# Altium schematic sheet power-board_E3_schematic.SchDoc
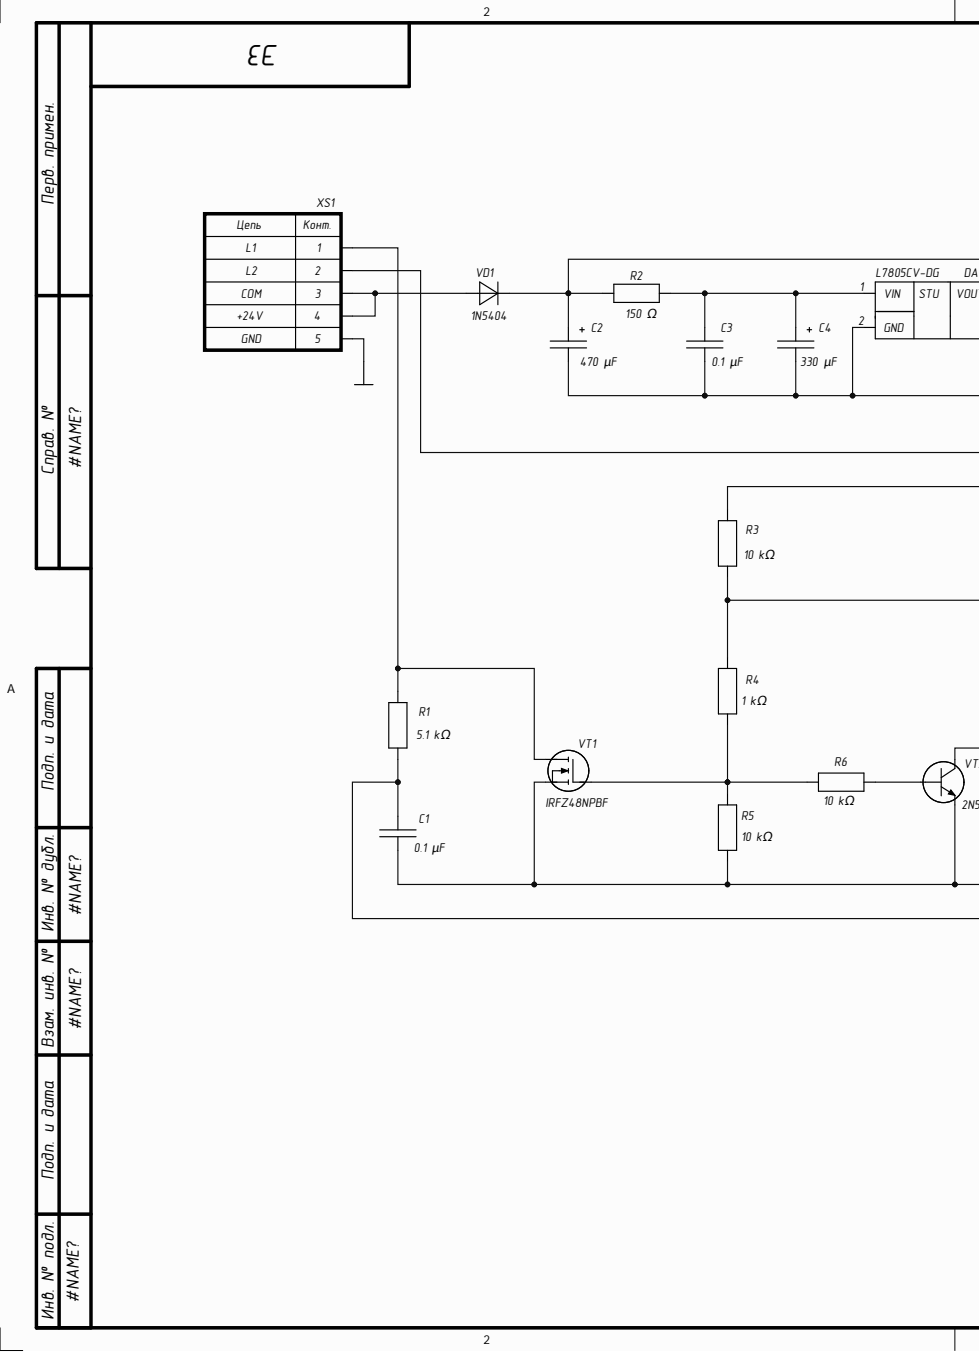
[diagram p0: power-board_E3_schematic.SchDoc, left]
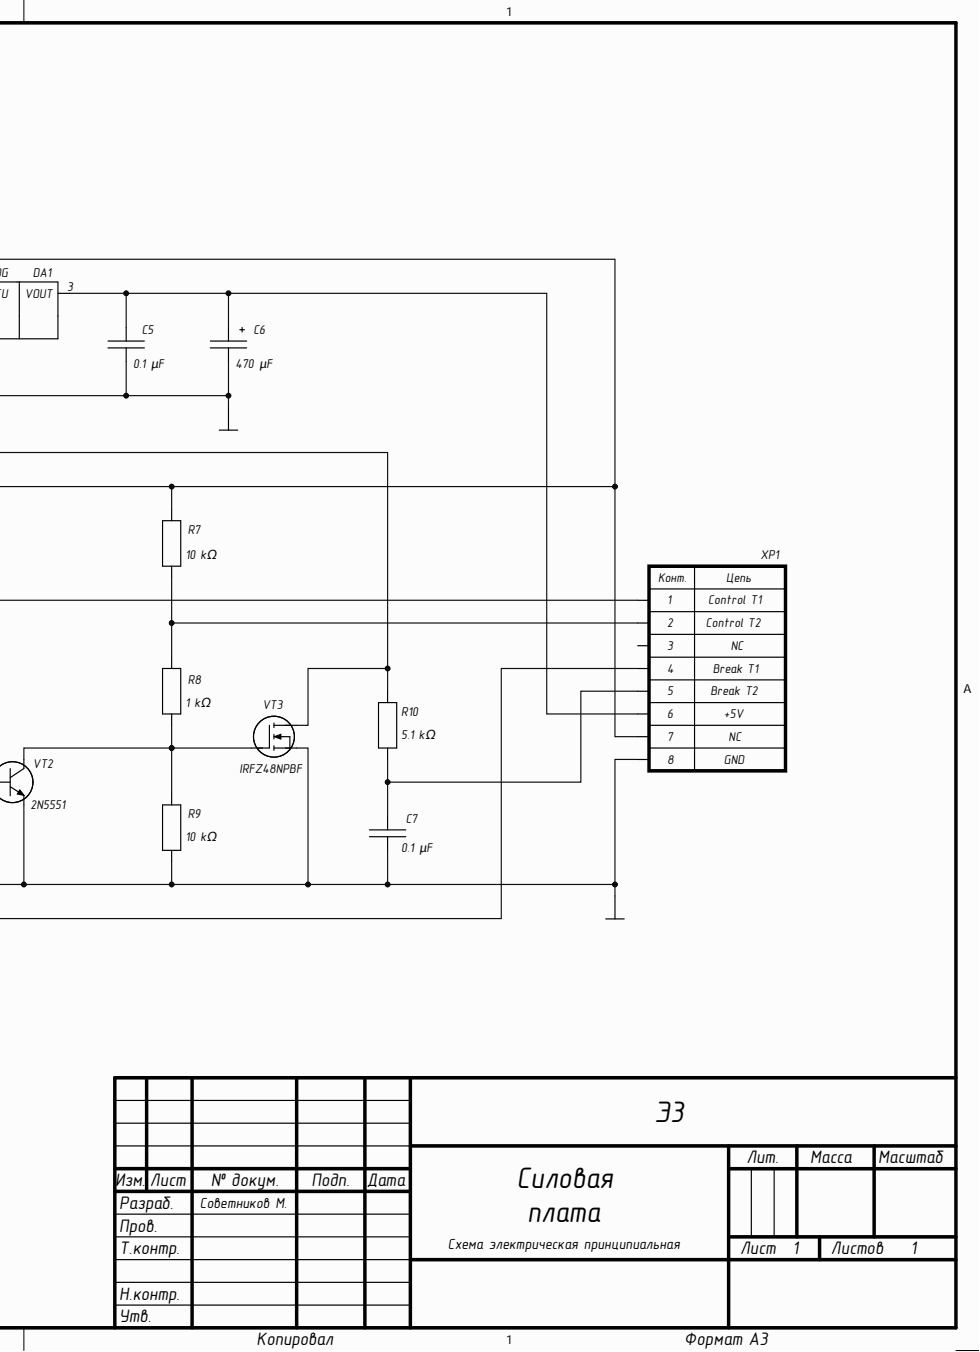
[diagram p1: power-board_E3_schematic.SchDoc, right]

## Parts
C1  0.1 µF  [C_MLCC]
C2  470 µF  [C_Polarized_D10_H16.5]
C3  0.1 µF  [C_MLCC]
C4  330 µF  [C_Polarized_D8_H16.5]
C5  0.1 µF  [C_MLCC]
C6  470 µF  [C_Polarized_D8_H13]
C7  0.1 µF  [C_MLCC]
DA1  L7805CV-DG  [DA_TO-220]
R1  5.1 kΩ  [R_CF-25_C1-4]
R2  150 Ω  [R_C2-23]
R3  10 kΩ  [R_CF-25_C1-4]
R4  1 kΩ  [R_CF-25_C1-4]
R5  10 kΩ  [R_CF-25_C1-4]
R6  10 kΩ  [R_CF-25_C1-4]
R7  10 kΩ  [R_CF-25_C1-4]
R8  1 kΩ  [R_CF-25_C1-4]
R9  10 kΩ  [R_CF-25_C1-4]
R10  5.1 kΩ  [R_CF-25_C1-4]
VD1  1N5404  [VD_DO-201AD]
VT1  IRFZ48NPBF  [VT_TO-220AB]
VT2  2N5551  [VT_TO-92]
VT3  IRFZ48NPBF  [VT_TO-220AB]
XP1  L-KLS1-2.50-08-R  [X_CWF-8R]  (value not drawn)
XS1  DG35C-B-05P-13-200A(H)  [X_DG35C-05PIN]  (value not drawn)

## Nets
GND: C1.1 C2.2 C3.1 C4.2 C5.1 C6.2 C7.1 DA1.2 R5.1 R9.2 VT1.1 VT2.3 VT3.1 XP1.8 XS1.5
NetC1_2: C1.2 R1.2 XP1.4
NetC2_1: C2.1 R2.1 R3.2 R7.2 VD1.2 XP1.7
NetC3_2: C3.2 C4.1 DA1.1 R2.2
NetC5_2: C5.2 C6.1 DA1.3 XP1.6
NetC7_2: C7.2 R10.2 XP1.5
NetR1_1: R1.1 VT1.3 XS1.1
NetR3_1: R3.1 R4.2 XP1.1
NetR4_1: R4.1 R5.2 R6.2 VT1.2
NetR6_1: R6.1 VT2.2
NetR7_1: R7.1 R8.2 XP1.2
NetR8_1: R8.1 R9.1 VT2.1 VT3.2
NetR10_1: R10.1 VT3.3 XS1.2
NetVD1_1: VD1.1 XS1.3 XS1.4

Not connected: XP1 3

## Library data of the parts
C1 C3 C5 C7 = C_NonPolarized: Ceramic capacitor, 50 V, 20%; Component Kind: Standard; Value: 0.1 µF; Library Name: aksima_ugo.SchLib
C2 C4 = C_Polarized: Aluminum electrolytic capacitor, 35 V, 20%; Component Kind: Standard; Library Name: aksima_ugo.SchLib
  C2: Value: 470 µF
  C4: Value: 330 µF
C6 = C_Polarized: Aluminum electrolytic capacitor, 16 V, 20%; Component Kind: Standard; Value: 470 µF; Library Name: aksima_ugo.SchLib
DA1 = DA_Voltage_Regulator: Linear voltage regulator, +5 V; Component Kind: Standard; Value: L7805CV-DG; Library Name: aksima_ugo.SchLib
R1 R3-R10 = R_Resistor: Resistor, 0.25 W, 5%; Component Kind: Standard; Library Name: aksima_ugo.SchLib
  R1 R10: Value: 5.1 kΩ
  R3 R5-R7 R9: Value: 10 kΩ
  R4 R8: Value: 1 kΩ
R2 = R_Resistor: Resistor, 2 W, 5%; Component Kind: Standard; Value: 150 Ω; Library Name: aksima_ugo.SchLib
VD1 = VD_Diode: Rectifier diode; Component Kind: Standard; Value: 1N5404; Library Name: aksima_ugo.SchLib
VT1 VT3 = VT_MOSFET-N: N-channel MOSFET; Component Kind: Standard; Value: IRFZ48NPBF; Library Name: aksima_ugo.SchLib
VT2 = VT_Bipolar-NPN: NPN bipolar transistor; Component Kind: Standard; Value: 2N5551; Library Name: aksima_ugo.SchLib
XP1 = XP_Connector_8Pin: 8-position connector; Component Kind: Standard; Value: L-KLS1-2.50-08-R; Library Name: aksima_ugo.SchLib
XS1 = XS_Connector_5Pin: 5-position terminal block; Component Kind: Standard; Value: DG35C-B-05P-13-200A(H); Library Name: aksima_ugo.SchLib
